# Altium schematic sheet P10_ESP32.SchDoc(P10_ESP32)
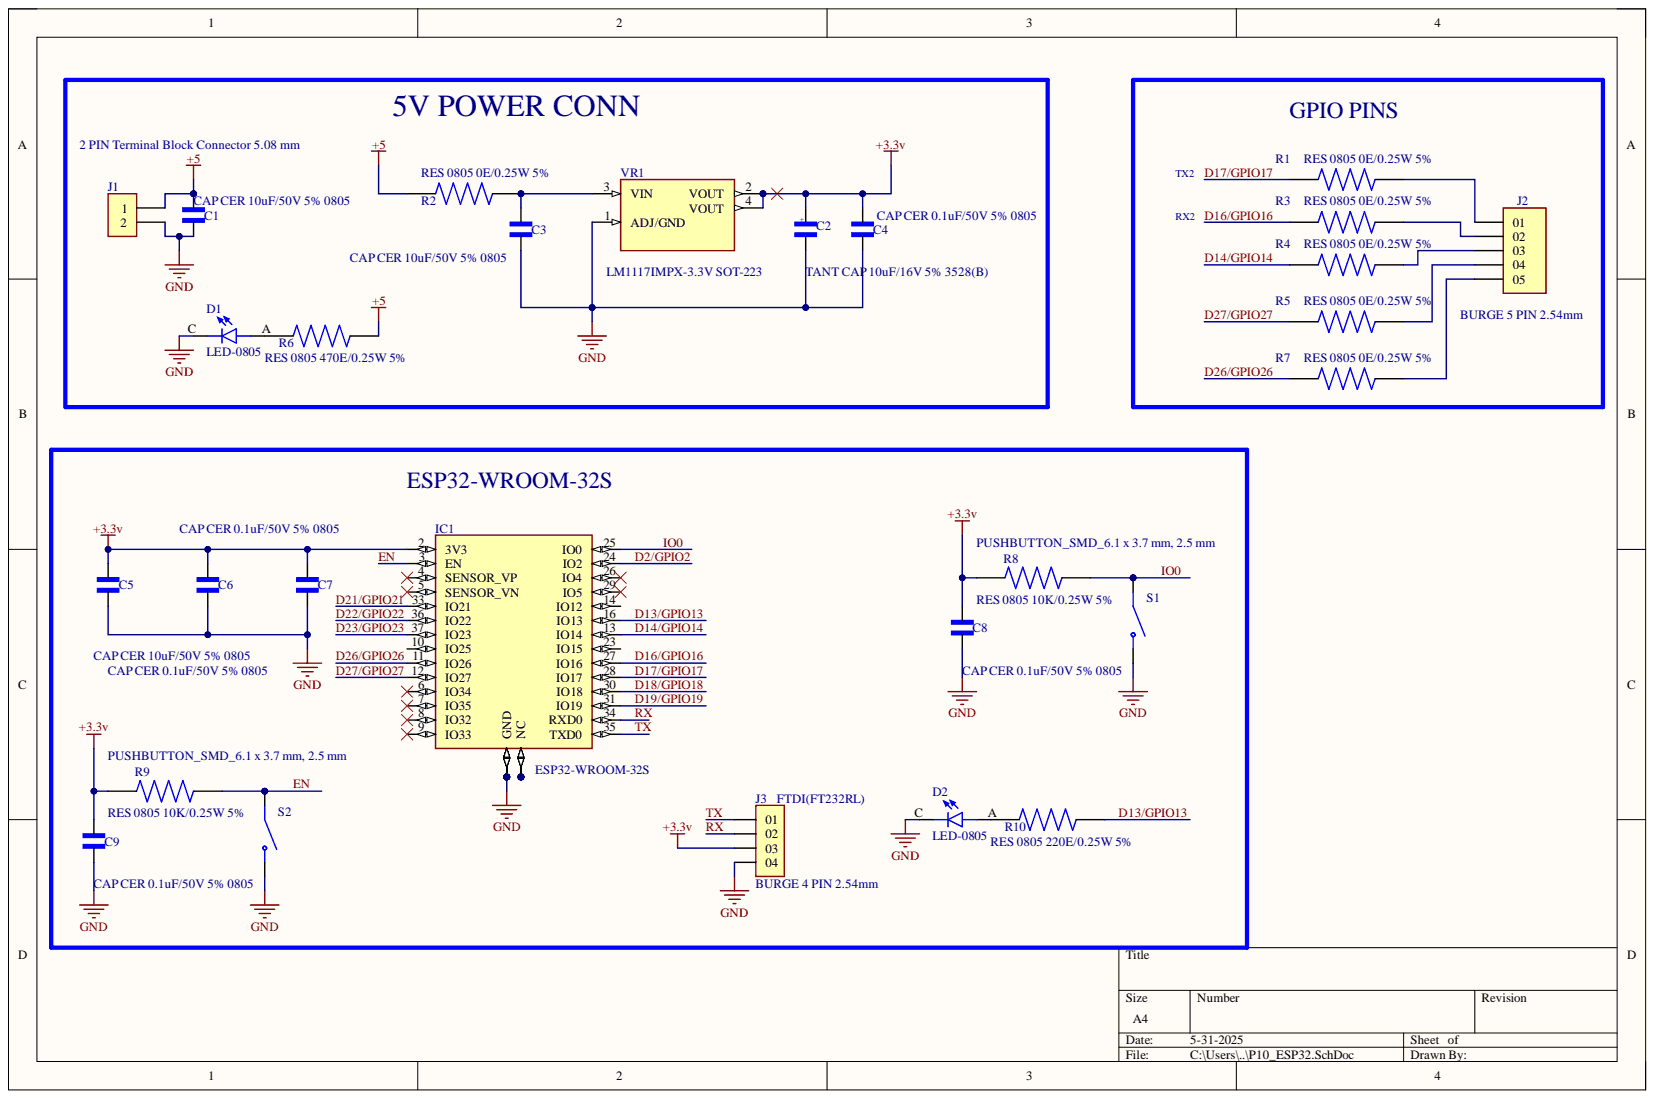
[diagram p0: P10_ESP32.SchDoc]

## Parts
C1  CAP CER 10uF/50V 5% 0805  [CAP CER 0.1uF/50V 5%]
C2  TANT CAP 10uF/16V 5% 3528(B)  [TANT CAP 3528(B)]
C3  CAP CER 10uF/50V 5% 0805  [CAP CER 0.1uF/50V 5%]
C4  CAP CER 0.1uF/50V 5% 0805  [CAP CER 0.1uF/50V 5%]
C5  CAP CER 10uF/50V 5% 0805  [CAP CER 0.1uF/50V 5%]
C6  CAP CER 0.1uF/50V 5% 0805  [CAP CER 0.1uF/50V 5%]
C7  CAP CER 0.1uF/50V 5% 0805  [CAP CER 0.1uF/50V 5%]
C8  CAP CER 0.1uF/50V 5% 0805  [CAP CER 0.1uF/50V 5%]
C9  CAP CER 0.1uF/50V 5% 0805  [CAP CER 0.1uF/50V 5%]
D1  LED-0805  [LED-0805]
D2  LED-0805  [LED-0805]
IC1  ESP32-WROOM-32S  [ESP32-WROOM-32S]
J1  2 PIN Terminal Block Connector 5.08 mm  [2 PIN Terminal Block Connector 5.08 mm]
J2  BURGE 5 PIN 2.54mm  [BURGE 5 PIN]
J3  BURGE 4 PIN 2.54mm  [BURGE 4 PIN 2.54mm]
R1  RES 0805 0E/0.25W 5%  [RES 0805 10K/0.25W 5%]
R2  RES 0805 0E/0.25W 5%  [RES 0805 10K/0.25W 5%]
R3  RES 0805 0E/0.25W 5%  [RES 0805 10K/0.25W 5%]
R4  RES 0805 0E/0.25W 5%  [RES 0805 10K/0.25W 5%]
R5  RES 0805 0E/0.25W 5%  [RES 0805 10K/0.25W 5%]
R6  RES 0805 470E/0.25W 5%  [RES 0805 10K/0.25W 5%]
R7  RES 0805 0E/0.25W 5%  [RES 0805 10K/0.25W 5%]
R8  RES 0805 10K/0.25W 5%  [RES 0805 10K/0.25W 5%]
R9  RES 0805 10K/0.25W 5%  [RES 0805 10K/0.25W 5%]
R10  RES 0805 220E/0.25W 5%  [RES 0805 10K/0.25W 5%]
S1  PUSHBUTTON_SMD_6.1 x 3.7 mm, 2.5 mm  [PUSHBUTTON_SMD_6.1 x 3.7 mm, 2.5 mm]
S2  PUSHBUTTON_SMD_6.1 x 3.7 mm, 2.5 mm  [PUSHBUTTON_SMD_6.1 x 3.7 mm, 2.5 mm]
VR1  LM1117IMPX-3.3V SOT-223  [LM1117IMPX-3.3V SOT-223]

## Nets
+3.3v: C2.1 C4.2 C5.2 C6.2 C7.2 C8.2 C9.2 IC1.2(3V3) J3.03 R8.1 R9.1 VR1.2(VOUT) VR1.4(VOUT)
+5: C1.2 J1.1 R2.1 R6.2
GND: C1.1 C2.2 C3.1 C4.1 C5.1 C6.1 C7.1 C8.1 C9.1 D1.C D2.C IC1.1(GND) IC1.15 IC1.38 IC1.39 IC1.40 IC1.41 IC1.42 IC1.43 IC1.44 IC1.45 IC1.46 IC1.47 J1.2 J3.04 S1.2 S2.2 VR1.1(ADJ/GND)
D2/GPIO2: IC1.24(IO2)
D13/GPIO13: IC1.16(IO13) R10.2
D14/GPIO14: IC1.13(IO14) R4.1
D16/GPIO16: IC1.27(IO16) R3.1
D17/GPIO17: IC1.28(IO17) R1.1
D18/GPIO18: IC1.30(IO18)
D19/GPIO19: IC1.31(IO19)
D21/GPIO21: IC1.33(IO21)
D22/GPIO22: IC1.36(IO22)
D23/GPIO23: IC1.37(IO23)
D26/GPIO26: IC1.11(IO26) R7.1
D27/GPIO27: IC1.12(IO27) R5.1
EN: IC1.3(EN) R9.2 S2.1
IO0: IC1.25(IO0) R8.2 S1.1
RX: IC1.34(RXD0) J3.02
TX: IC1.35(TXD0) J3.01
NetC3_2: C3.2 R2.2 VR1.3(VIN)
NetD1_A: D1.A R6.1
NetD2_A: D2.A R10.1
NetIC1_17: IC1.17(NC) IC1.18 IC1.19 IC1.20 IC1.21 IC1.22 IC1.32
NetJ2_01: J2.01 R1.2
NetJ2_02: J2.02 R3.2
NetJ2_03: J2.03 R4.2
NetJ2_04: J2.04 R5.2
NetJ2_05: J2.05 R7.2

Not connected: IC1 4(SENSOR_VP) 5(SENSOR_VN) 6(IO34) 7(IO35) 8(IO32) 9(IO33) 10(IO25) 14(IO12) 23(IO15) 26(IO4) 29(IO5)

## Library data of the parts
C1 C3-C9 = CAP CER 0.1uF/50V 5%: CAP CER 0.1uF/50V  Ceramic Capacitor X7R 0805 (2012 Metric); MF: Samsung; MP: CL21B104KCFNNNE; Check_prices: https://www.snapeda.com/parts/CL21B104KCFNNNE/Samsung+Electro-Mechanics/view-part/?ref=eda; Component Kind: Standard; Digi-Key_Part_Number: 1276-6840-2-ND; Library Name: P10_ATMEGA328P.SchLib; Package: 0805 Samsung; Purchase-URL: https://pricing.snapeda.com/search?q=CL21B104KCFNNNE&ref=eda; SnapEDA_Link: https://www.snapeda.com/parts/CL21B104KCFNNNE/Samsung+Electro-Mechanics/view-part/?ref=snap
C2 = TANT CAP 10uF/16V 5% 3528(B): 10 ÂµF Molded Tantalum Polymer Capacitor 25 V 1411 (3528 Metric), 1210 150mOhm TCJB106M025R0150; MF: AVX; Check_prices: https://www.snapeda.com/parts/TCJB106M025R0150/AVX/view-part/?ref=eda; Component Kind: Standard; Library Name: P10_ESP32.SchLib; MP: TCJB106M025R0150; Package: 1210 AVX; SnapEDA_Link: https://www.snapeda.com/parts/TCJB106M025R0150/AVX/view-part/?ref=snap
D1 D2 = LED-0805: Red 640nm LED Indication - Discrete 1.85V 0805 (2012 Metric) APT2012SRCPRV; MF: Kingbright; Check_prices: https://www.snapeda.com/parts/APT2012SRCPRV/Kingbright/view-part/?ref=eda; Component Kind: Standard; Library Name: P10_ESP32.SchLib; MP: APT2012SRCPRV; Package: 0805 Kingbright; SnapEDA_Link: https://www.snapeda.com/parts/APT2012SRCPRV/Kingbright/view-part/?ref=snap
IC1 = ESP32-WROOM-32S: Bluetooth, WiFi 802.11b/g/n, Bluetooth v4.2 +EDR, Class 1, 2 and 3 Transceiver Module 2.4GHz ~ 2.5GHz PCB Trace Surface Mount; MF: Espressif Systems; MP: ESP32-WROOM-32E-N8; Check_prices: https://www.snapeda.com/parts/ESP32-WROOM-32E-N8/Espressif+Systems/view-part/?ref=eda; Component Kind: Standard; Library Name: P10_ESP32.SchLib; Package: SMD-44 Espressif Systems; SnapEDA_Link: https://www.snapeda.com/parts/ESP32-WROOM-32E-N8/Espressif+Systems/view-part/?ref=snap
J1 = 2 PIN Terminal Block Connector 5.08 mm: MOLEX,Screw Type, Horizontal, 5.08 Pitch, 24~12 (AWG), Terminal Block Connector, TB007-508-02BE; MF: Same Sky; Check_prices: https://www.snapeda.com/parts/TB007-508-02BE/Same+Sky/view-part/?ref=eda; Component Kind: Standard; Library Name: P10_ATMEGA328P.SchLib; MP: TB007-508-02BE; Package: None; SnapEDA_Link: https://www.snapeda.com/parts/TB007-508-02BE/Same+Sky/view-part/?ref=snap
J2 = BURGE 5 PIN: MTSW-105-07-T-S-048 BURGE 5 PIN 2.54mm; Availability: Unavailable; Component Kind: Standard; Library Name: P10_ATMEGA328P.SchLib; MP: MTSW-105-07-T-S-048; Package: None; Price: None
J3 = BURGE 4 PIN 2.54mm: Conn Unshrouded Header HDR 4 POS 2.54mm Solder ST Top Entry Thru-Hole Bulk TSW-104-17-G-S-LL; MF: Samtec; MP: TSW-104-23-H-S-LL; Check_prices: https://www.snapeda.com/parts/TSW-104-23-H-S-LL/Samtec/view-part/?ref=eda; Component Kind: Standard; Library Name: P10_ESP32.SchLib; Package: None; SnapEDA_Link: https://www.snapeda.com/parts/TSW-104-23-H-S-LL/Samtec/view-part/?ref=snap
R1-R10 = RES 0805 10K/0.25W 5%: RESISTOR, THICK FILM, 10K, 5%, 0805, FRESISTOR, THICK FILM, 10K, 5%, 0805, FULL REEL Product Range:PANASONIC - ERJ Series; MF: Panasonic Electronic; MP: ERJ-6GEYJ103V; Availability: In Stock; Check_prices: https://www.snapeda.com/parts/ERJ-6GEYJ103V/Panasonic+Electronic+Components/view-part/?ref=eda; Component Kind: Standard; Library Name: P10_ATMEGA328P.SchLib; Package: 805-2 Panasonic; Price: None; Purchase-URL: https://pricing.snapeda.com/search/part/ERJ-6GEYJ103V/?ref=eda; SnapEDA_Link: https://www.snapeda.com/parts/ERJ-6GEYJ103V/Panasonic+Electronic+Components/view-part/?ref=snap
S1 S2 = PUSHBUTTON_SMD_6.1 x 3.7 mm, 2.5 mm: 6.1 x 3.7 mm, 2.5 mm Actuator Height, 260 gf, Red, Surface Mount, SPST, Tactile Switch, TS09-63-25-R-260-SMT-TR; MF: Same Sky; Check_prices: https://www.snapeda.com/parts/TS09-63-25-R-260-SMT-TR/Same+Sky/view-part/?ref=eda; Component Kind: Standard; Library Name: P10_ATMEGA328P.SchLib; MP: TS09-63-25-R-260-SMT-TR; Package: None; SnapEDA_Link: https://www.snapeda.com/parts/TS09-63-25-R-260-SMT-TR/Same+Sky/view-part/?ref=snap
VR1 = LM1117IMPX-3.3V SOT-223: LM1117IMPX-3.3V 800-mA 15-V linear voltage regulator SOT-223; MF: Texas Instruments; MP: LM1117IMPX-5.0/NOPB; Check_prices: https://www.snapeda.com/parts/LM1117IMPX-5.0/NOPB/Texas+Instruments/view-part/?ref=eda; Component Kind: Standard; Library Name: P10_ESP32.SchLib; Package: SOT-223-4 Texas Instruments; SnapEDA_Link: https://www.snapeda.com/parts/LM1117IMPX-5.0/NOPB/Texas+Instruments/view-part/?ref=snap
